# Altium schematic sheet MCU.SchDoc(MCU)
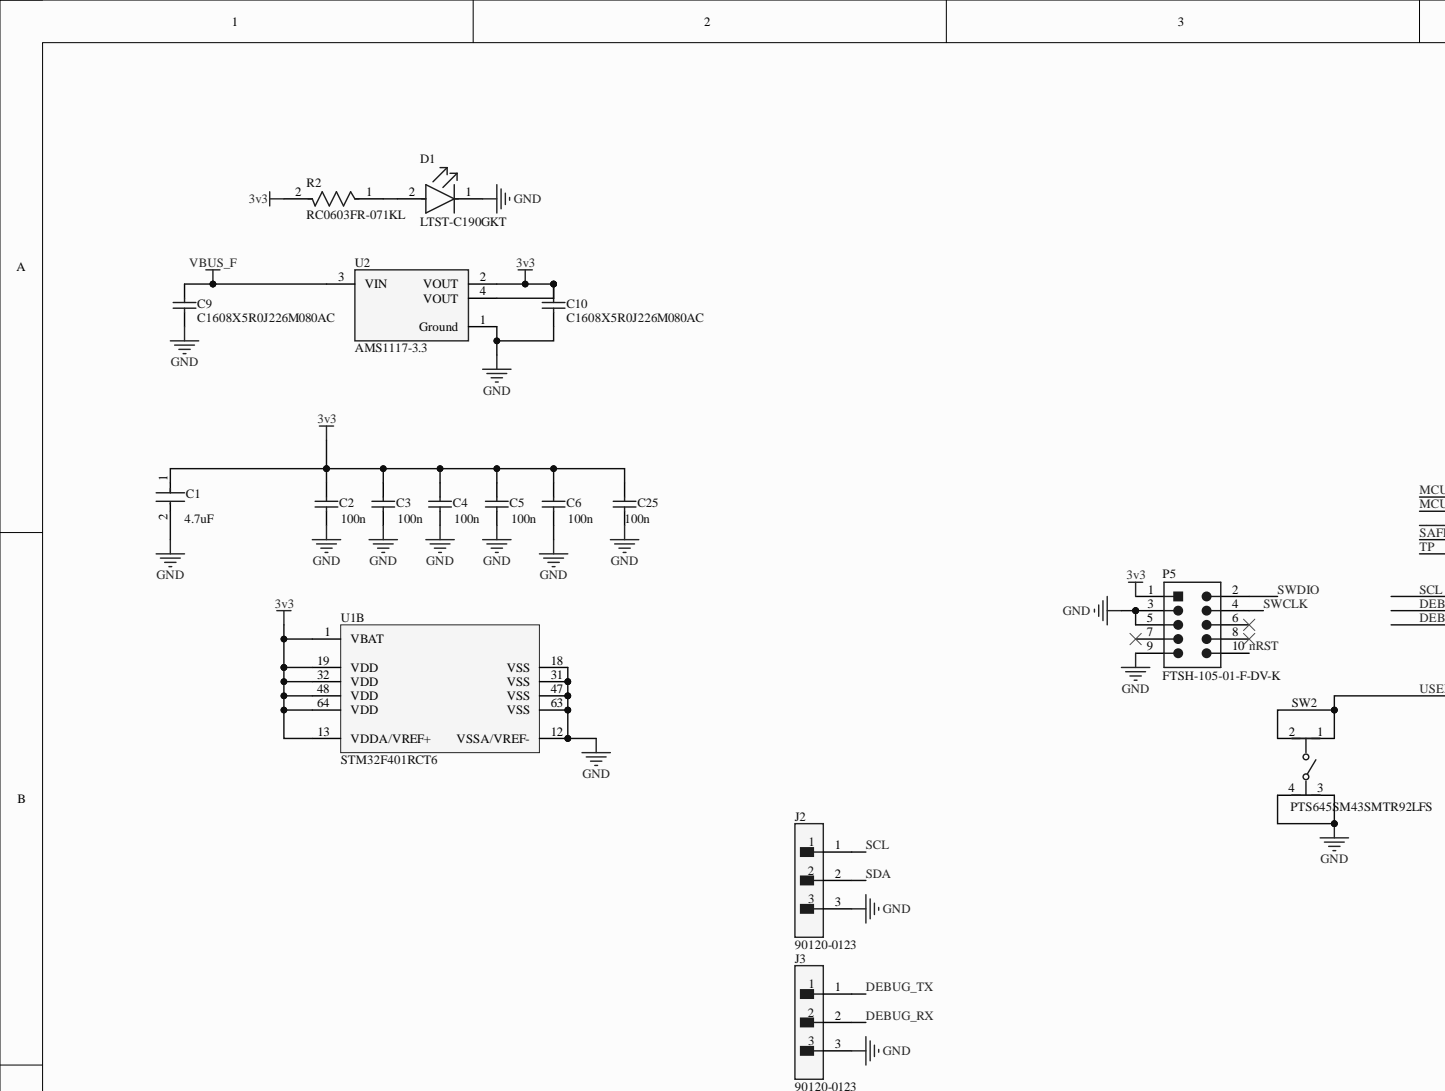
[diagram p0: MCU.SchDoc, top left]
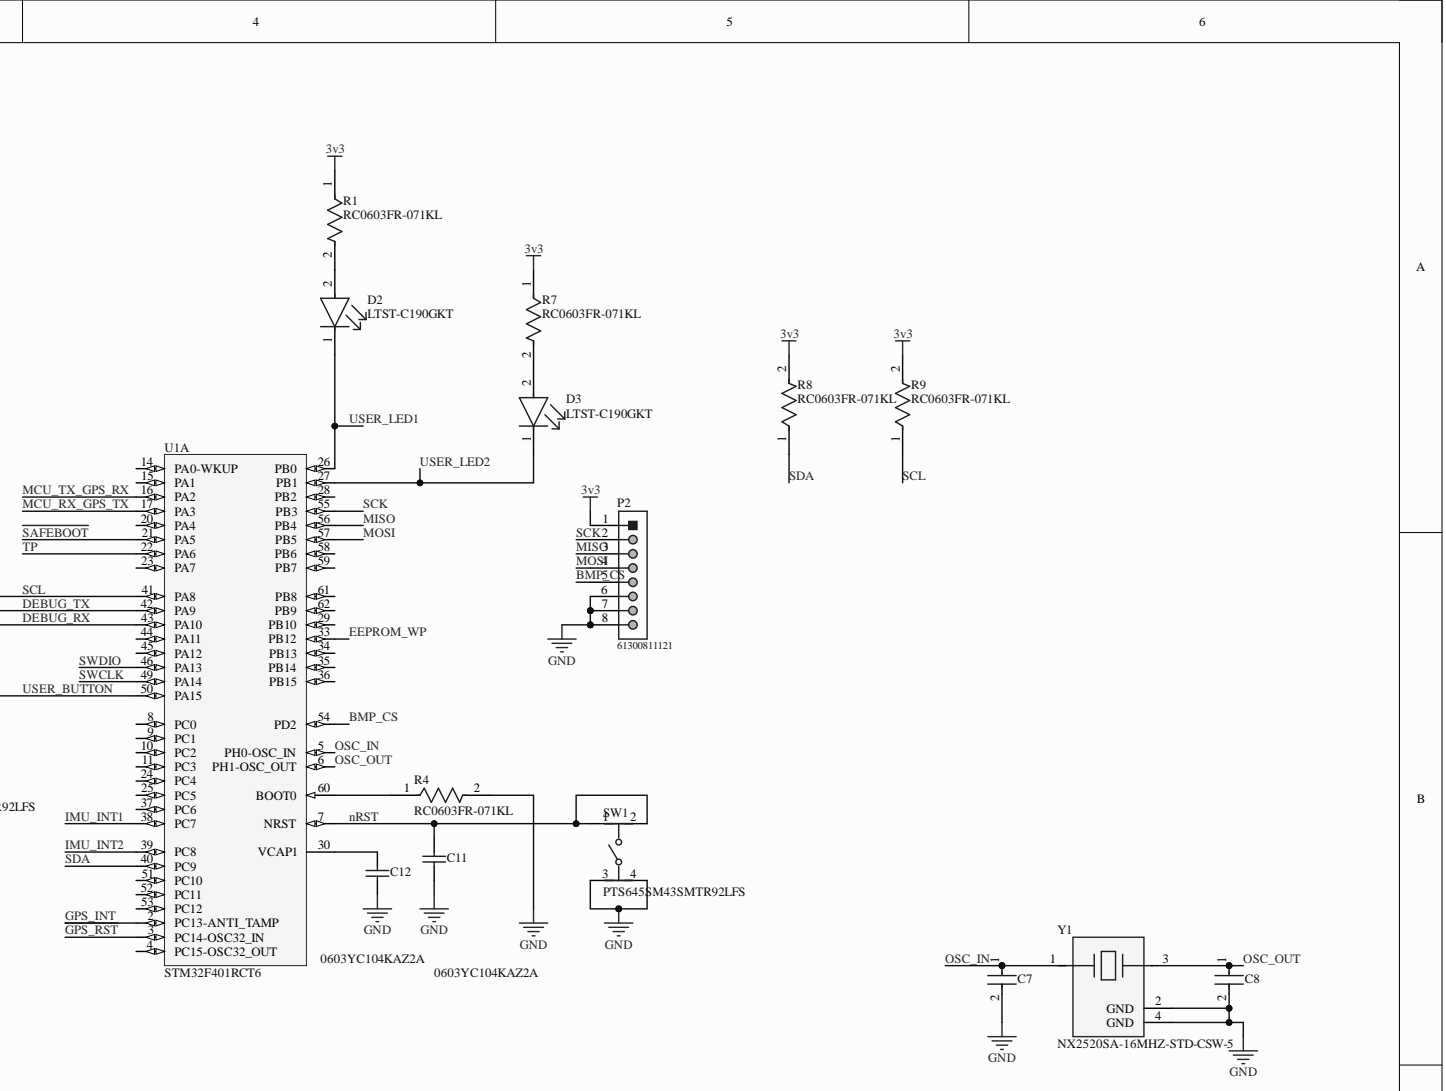
[diagram p1: MCU.SchDoc, top right]
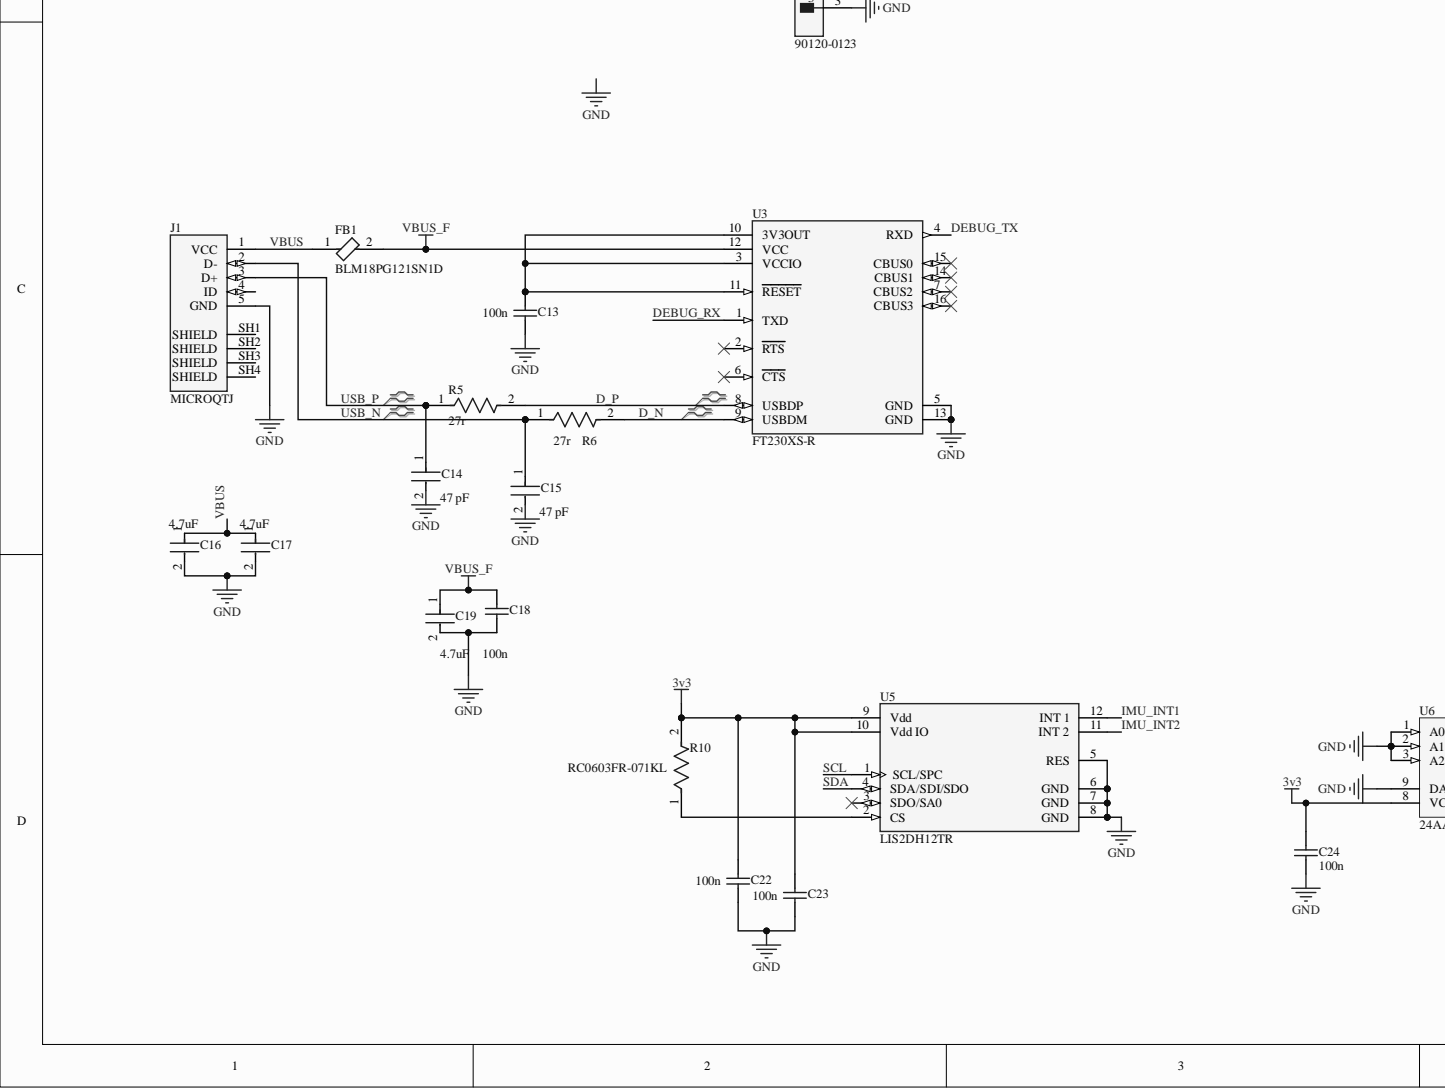
[diagram p2: MCU.SchDoc, bottom left]
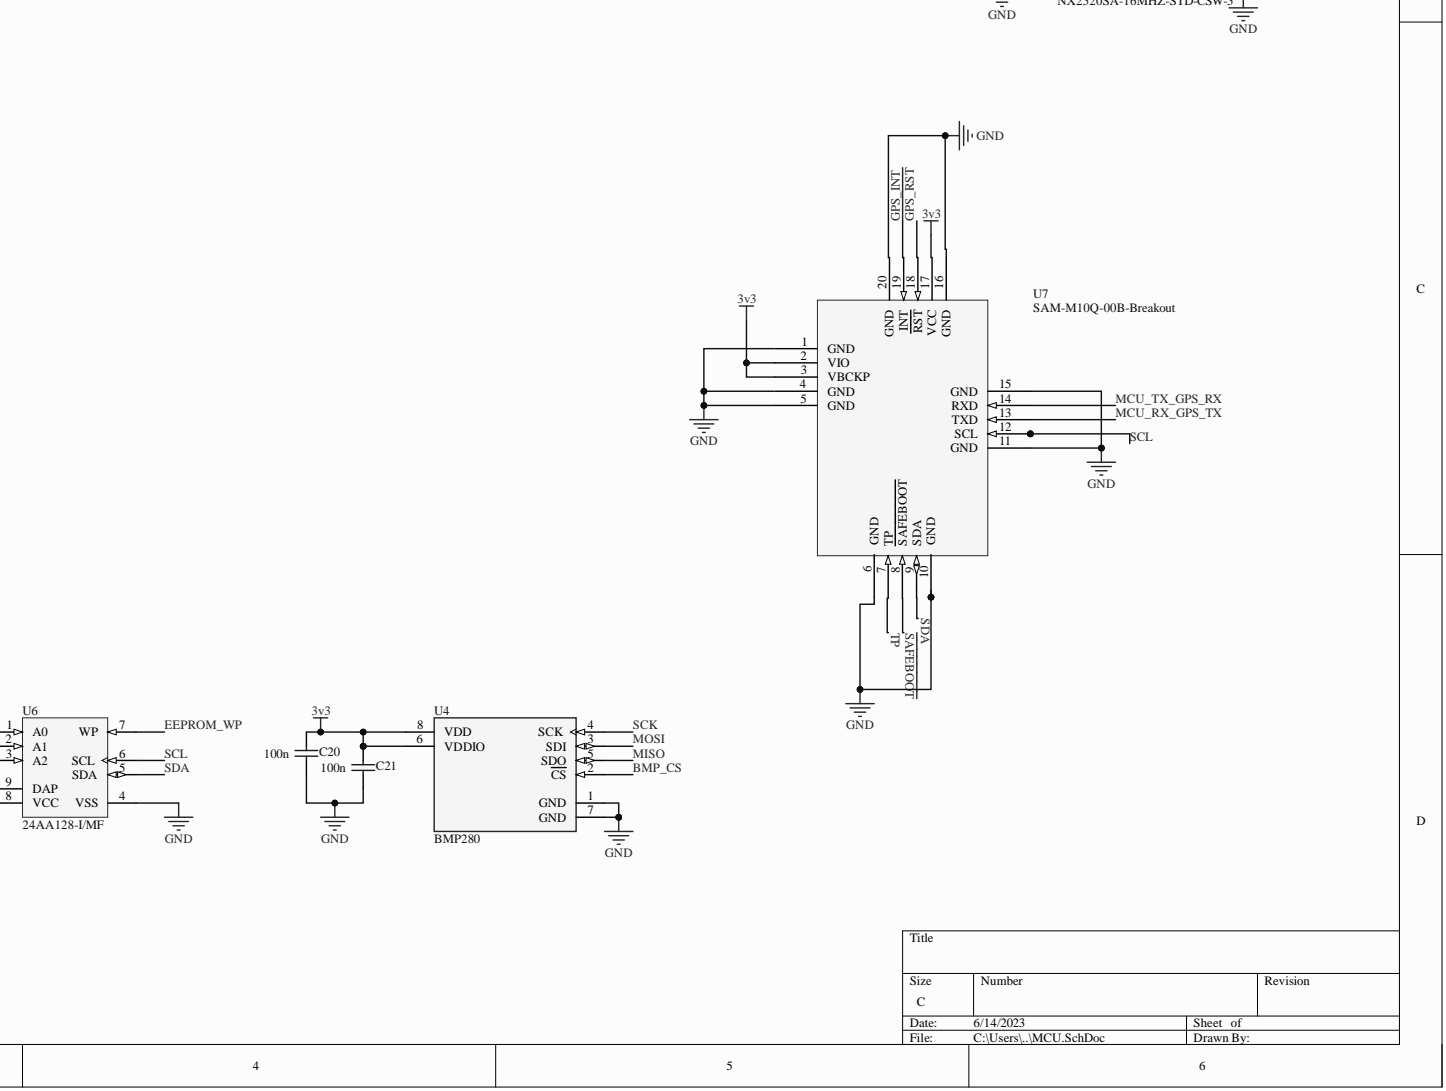
[diagram p3: MCU.SchDoc, bottom right]

## Parts
C1  value 4.7uF  Capacitance: 4.7uF  [FP-C0603-CG-MFG]
C2  100n  [CAPC1608X90X35ML15T15]
C3  100n  [CAPC1608X90X35ML15T15]
C4  100n  [CAPC1608X90X35ML15T15]
C5  100n  [CAPC1608X90X35ML15T15]
C6  100n  [CAPC1608X90X35ML15T15]
C7  (no value)  [FP-C1608-080-0_1-IPC_A]
C8  (no value)  [FP-C1608-080-0_1-IPC_A]
C9  C1608X5R0J226M080AC  [CAPC1608X100X20ML10]
C10  C1608X5R0J226M080AC  [CAPC1608X100X20ML10]
C11  0603YC104KAZ2A  [CAPC1608X90X35ML15T15]
C12  0603YC104KAZ2A  [CAPC1608X90X35ML15T15]
C13  100n  [CAPC1608X90X35ML15T15]
C14  value 47 pF  Capacitance: 47 pF  [FP-C0603C-CF-MFG]
C15  value 47 pF  Capacitance: 47 pF  [FP-C0603C-CF-MFG]
C16  value 4.7uF  Capacitance: 4.7uF  [FP-C0603-CG-MFG]
C17  value 4.7uF  Capacitance: 4.7uF  [FP-C0603-CG-MFG]
C18  100n  [CAPC1608X90X35ML15T15]
C19  value 4.7uF  Capacitance: 4.7uF  [FP-C0603-CG-MFG]
C20  100n  [CAPC1608X90X35ML15T15]
C21  100n  [CAPC1608X90X35ML15T15]
C22  100n  [CAPC1608X90X35ML15T15]
C23  100n  [CAPC1608X90X35ML15T15]
C24  100n  [CAPC1608X90X35ML15T15]
C25  100n  [CAPC1608X90X35ML15T15]
D1  LTST-C190GKT  [FP-LTST-C190GKT-MFG]
D2  LTST-C190GKT  [FP-LTST-C190GKT-MFG]
D3  LTST-C190GKT  [FP-LTST-C190GKT-MFG]
FB1  BLM18PG121SN1D  [FP-BLM18-0_15-t0_8-IPC_A]
J1  MICROQTJ  [SHOUHAN_MICROQTJ]
J2  90120-0123  [FP-90120-0123-MFG]
J3  90120-0123  [FP-90120-0123-MFG]
P2  61300811121  [61300811121]
P5  FTSH-105-01-F-DV-K  [SMTC-FTSH-105-01-L-DV-K_V]
R1  RC0603FR-071KL  [FP-RC0603-0_55-IPC_C]
R2  RC0603FR-071KL  [FP-RC0603-0_55-IPC_C]
R4  RC0603FR-071KL  [FP-RC0603-0_55-IPC_C]
R5  27r  [FP-CRCW0603-e3-IPC_B]
R6  27r  [FP-CRCW0603-e3-IPC_B]
R7  RC0603FR-071KL  [FP-RC0603-0_55-IPC_C]
R8  RC0603FR-071KL  [FP-RC0603-0_55-IPC_C]
R9  RC0603FR-071KL  [FP-RC0603-0_55-IPC_C]
R10  RC0603FR-071KL  [FP-RC0603-0_55-IPC_C]
SW1  PTS645SM43SMTR92LFS  [FP-PTS645SM43SMTR92LFS-MFG]
SW2  PTS645SM43SMTR92LFS  [FP-PTS645SM43SMTR92LFS-MFG]
U1  STM32F401RCT6  [STM-LQFP64_M]  (parts A,B)
U2  AMS1117-3.3  [FP-AMS1117-IPC_C]
U3  FT230XS-R  [FP-SSOP-16-MFG]
U4  BMP280  [FP-BMP280-MFG]
U5  LIS2DH12TR  [FP-LGA-12-8365767-MFG]
U6  24AA128-I/MF  [DFNS-MF8_PS]
U7  SAM-M10Q-00B-Breakout  [SAM-M10Q-00B]
Y1  NX2520SA-16MHZ-STD-CSW-5  [FP-NX2520SA-MFG]

## Nets
3v3: C1.1 C2.2 C3.2 C4.2 C5.2 C6.2 C10.1 C20.2 C21.2 C22.2 C23.2 C24.2 C25.2 P2.1 P5.1 R1.1 R2.2 R7.1 R8.2 R9.2 R10.2 U1.1(VBAT) U1.13(VDDA/VREF+) U1.19(VDD) U1.32(VDD) U1.48(VDD) U1.64(VDD) U2.2(VOUT) U2.4(VOUT) U4.6(VDDIO) U4.8(VDD) U5.9(Vdd) U5.10(Vdd_IO) U6.8(VCC) U7.2(VIO) U7.3(VBCKP) U7.17(VCC)
GND: C1.2 C2.1 C3.1 C4.1 C5.1 C6.1 C7.2 C8.2 C9.2 C10.2 C11.1 C12.1 C13.1 C14.2 C15.2 C16.2 C17.2 C18.1 C19.2 C20.1 C21.1 C22.1 C23.1 C24.1 C25.1 D1.1 J1.5(GND) J2.3 J3.3 P2.6 P2.7 P2.8 P5.3 P5.5 P5.9 R4.2 SW1.3 SW1.4 SW2.3 SW2.4 U1.12(VSSA/VREF-) U1.18(VSS) U1.31(VSS) U1.47(VSS) U1.63(VSS) U2.1(Ground) U3.5(GND) U3.13(GND) U4.1(GND) U4.7(GND) U5.5(RES) U5.6(GND) U5.7(GND) U5.8(GND) U6.1(A0) U6.2(A1) U6.3(A2) U6.4(VSS) U6.9(DAP) U7.5(GND) U7.6(GND) U7.10(GND) U7.11(GND) U7.15(GND) U7.16(GND) U7.20(GND) Y1.2(GND) Y1.4(GND)
VBUS_F: C9.1 C18.2 C19.1 FB1.2 U2.3(VIN) U3.12(VCC)
BMP_CS: P2.5 U1.54(PD2) U4.2(CS)
D_N: R6.2 U3.9(USBDM)
D_P: R5.2 U3.8(USBDP)
DEBUG_RX: J3.2 U1.43(PA10) U3.1(TXD)
DEBUG_TX: J3.1 U1.42(PA9) U3.4(RXD)
EEPROM_WP: U1.33(PB12) U6.7(WP)
~{GPS_RST}: U1.3(PC14-OSC32_IN) U7.18(RST)
GPS_INT: U1.2(PC13-ANTI_TAMP) U7.19(INT)
IMU_INT1: U1.38(PC7) U5.12(INT_1)
IMU_INT2: U1.39(PC8) U5.11(INT_2)
MCU_RX_GPS_TX: U1.17(PA3) U7.13(TXD)
MCU_TX_GPS_RX: U1.16(PA2) U7.14(RXD)
MISO: P2.3 U1.56(PB4) U4.5(SDO)
MOSI: P2.4 U1.57(PB5) U4.3(SDI)
nRST: C11.2 P5.10 SW1.1 SW1.2 U1.7(NRST)
OSC_IN: C7.1 U1.5(PH0-OSC_IN) Y1.1
OSC_OUT: C8.1 U1.6(PH1-OSC_OUT) Y1.3
~{SAFEBOOT}: U1.21(PA5) U7.8(SAFEBOOT)
SCK: P2.2 U1.55(PB3) U4.4(SCK)
SCL: J2.1 R9.1 U1.41(PA8) U5.1(SCL/SPC) U6.6(SCL) U7.12(SCL)
SDA: J2.2 R8.1 U1.40(PC9) U5.4(SDA/SDI/SDO) U6.5(SDA) U7.9(SDA)
SWCLK: P5.4 U1.49(PA14)
SWDIO: P5.2 U1.46(PA13)
TP: U1.22(PA6) U7.7(TP)
USB_N: C15.1 J1.2(D-) R6.1
USB_P: C14.1 J1.3(D+) R5.1
USER_BUTTON: SW2.1 SW2.2 U1.50(PA15)
USER_LED1: D2.1 U1.26(PB0)
USER_LED2: D3.1 U1.27(PB1)
VBUS: C16.1 C17.1 FB1.1 J1.1(VCC)
NetC12_2: C12.2 U1.30(VCAP1)
NetC13_2: C13.2 U3.3(VCCIO) U3.10(3V3OUT) U3.11(RESET)
NetD1_2: D1.2 R2.1
NetD2_2: D2.2 R1.2
NetD3_2: D3.2 R7.2
NetR4_1: R4.1 U1.60(BOOT0)
NetR10_1: R10.1 U5.2(CS)

Not connected: J1 4(ID) SH1(SHIELD) SH2(SHIELD) SH3(SHIELD) SH4(SHIELD); P5 6 7 8; U1 4(PC15-OSC32_OUT) 8(PC0) 9(PC1) 10(PC2) 11(PC3) 14(PA0-WKUP) 15(PA1) 20(PA4) 23(PA7) 24(PC4) 25(PC5) 28(PB2) 29(PB10) 34(PB13) 35(PB14) 36(PB15) 37(PC6) 44(PA11) 45(PA12) 51(PC10) 52(PC11) 53(PC12) 58(PB6) 59(PB7) 61(PB8) 62(PB9); U3 2(RTS) 6(CTS) 7(CBUS2) 14(CBUS1) 15(CBUS0) 16(CBUS3); U5 3(SDO/SA0); U7 1(GND) 4(GND)

## Library data of the parts
C1 C16 C17 C19 = CMP-2006-02256-2: CAP CER 4.7UF 6.3V X5R 0603; Manufacturer: Kemet; Manufacturer Part Number: C0603C475K9PACTU; Material: Ceramic; Temperature Coefficient: 15%; Tolerance: 10%; Automotive: No; Category: Cap; Component Kind: Standard; ComponentLink2Description: Datasheet; ComponentLink2URL: https://content.kemet.com/datasheets/KEM_C1006_X5R_SMD.pdf; Device Class L1: Passive Components; Device Class L2: Capacitors; Device Class L3: Ceramic Capacitors; Digikey Description: CAP CER 4.7UF 6.3V X5R 0603; Height: 0.9mm; Ipc Land Pattern Name: CAPC16080X80; Lead Free: Yes; Library Name: Altium Content Vault; Mouser Description: Multilayer Ceramic Capacitors MLCC - SMD/SMT 6.3volts 4.7uF X5R 10%; Octopart: https://octopart.com/c0603c475k9pactu-kemet-104242; Package: C0603-CG; RoHS: TRUE; Temperature: -55°C to +85°C; Temperature Characteristic: X5R; Temperature Range High: +85°C; Temperature Range Low: -55°C; Voltage Rating: 6.3V
C2-C6 C13 C18 C20-C25 = CMP-2006-04496-1: Manufacturer: AVX Interconnect / Elco; Part Number: 0603YC104KAZ2A; Component Kind: Standard; Library Name: Altium Content Vault
C7 C8 = CMP-08246-005081-1: Multilayer Ceramic Capacitors 8pF ±0.5pF 50V C0G SMD 0603; Insulation Resistance: 10GR; Capacitance: 8pF; Case Code (Imperial): 0603; Case Code (Metric): 1608; Case/Package: 0603; Component Kind: Standard; Construction: Flat; Depth: 0.8mm; Dielectric: C0G; Dielectric Material: Ceramic; Height: 0.8mm; Lead Free: Lead Free; Length: 1.6mm; Library Name: Altium Content Vault; Max Operating Temperature: 125°C; Min Operating Temperature: -55°C; Mount: Surface Mount; Package Quantity: 1; Packaging: Tape and Reel; Radiation Hardening: No; REACH SVHC: No SVHC; RoHS Compliant: Yes; Series: C; Termination: SMD/SMT; Thickness: 0.037inch; Voltage Rating: 50V; Voltage Rating (DC): 50V; Weight: 7.1E-05oz; Width: 0.031inch
C9 C10 = CMP-2000-05440-1: Chip Capacitor, 22 uF, +/- 20%, 6.3 V, -55 to 85 degC, 1608 (0603 Metric), RoHS, Tape and Reel; Manufacturer: TDK; PCN Part Number: MLCCPartNumberChange30/Nov/2012; Capacitance: 22µF; Design Resources: 20WNon-IsolatedBuckLEDDriverUsingPLLNK460KG; Kits: 445-9003-KIT-ND-KITCAPCERHICAPMLCCSMD; Online Catalog: CSeries,GeneralPurpose; Other Names: 445-8028-1; Product Training Modules: CSeriesGeneralApplicationsSEAT,CCV,andTVCLDesignToolsGuidetoReplacinganElectrolyticCapacitorwithanMLCC; Temperature Coefficient: X5R; Voltage - Rated: 6.3V; Applications: GeneralPurpose; Category: Capacitors; Component Kind: Standard; Datasheet URL: http://www.farnell.com/datasheets/1913358.pdf; Datasheet Version: Mar-15; Family: CeramicCapacitors; Features: -; Height - Seated (Max): -; Lead Spacing: -; Lead Style: -; Library Name: Altium Content Vault; Manufacturer URL: http://www.tdk.com/; Mounting Type: SurfaceMount,MLCC; Operating Temperature: -55degC~85degC; Package / Case: 0603(1608Metric); Package Description: 2-Pin Surface Mount Device, Body 1.6 x 0.8 mm; Package Reference: 603; Packaging: CutTape(CT); Ratings: -; RoHS Information: RoHSCertificate-MLCC; Series: C; Size / Dimension: 0.063"Lx0.031"W(1.60mmx0.80mm); Standard Package: 1; Thickness (Max): 0.039"(1.00mm); Tolerance: ±20%
C11 C12 = CMP-2006-04496-1: Manufacturer: AVX Interconnect / Elco; Component Kind: Standard; Library Name: Altium Content Vault; Part Number: 0603YC104KAZ2A
C14 C15 = CMP-2006-03321-2: CAP CER 47PF 100V 5% NP0 0603; Manufacturer: KEMET; Manufacturer Part Number: C0603C470J1GACTU; Automotive Grade: Grade 1; Material: Ceramic; Temperature Characteristic: C0G; Temperature Coefficient: 30ppm/°C; Tolerance: 0.05; Automotive: Yes; Category: Cap; Component Kind: Standard; ComponentLink2Description: Datasheet; ComponentLink2URL: https://api.kemet.com/component-edge/download/datasheet/C0603C100J5GACAUTO.pdf; Device Class L1: Passive Components; Device Class L2: Capacitors; Device Class L3: Ceramic Capacitors; Digikey Description: CAP CER 47PF 100V 5% NP0 0603; Height: 0.87mm; Lead Free: Yes; Library Name: Altium Content Vault; Mouser Description: Multilayer Ceramic Capacitors MLCC - SMD/SMT 100volts 47pF C0G 5%; Octopart: https://octopart.com/c0603c470j1gactu-kemet-3768219; Package: C0603C-CF; RoHS: TRUE; Temperature: -55°C to +125°C; Temperature Range High: +125°C; Temperature Range Low: -55°C; Voltage Rating: 100 V
D1-D3 = CMP-22017-000005-2: LED GREEN CLEAR CHIP SMD; Dominant Wavelength: 569nm; Forward Current: 30mA; Forward Voltage: 2.1V; Lens Color: Colorless; Luminous Intensity: 6mcd; Number of Elements: 1; Power Dissipation: 100mW; Reverse Voltage: 5V; Test Current: 10mA; Viewing Angle: 130°; Voltage: 2V; Wavelength: 565nm; Case Code (Imperial): 0603; Case Code (Metric): 1608; Case/Package: 0603; Color: Green; Component Kind: Standard; Depth: 0.8mm; Height: 0.8mm; Illumination Color: Green; Lead Free: Lead Free; Length: 1.6mm; Lens Style: Clear; Lens Transparency: Clear; Library Name: Altium Content Vault; Max Operating Temperature: 85°C; Min Operating Temperature: -55°C; Mount: Surface Mount; Number of LEDs: 1; Number of Pins: 2; Package Quantity: 1; Packaging: Tape and Reel; Power Rating: 100mW; Radiation Hardening: No; Width: 0.8mm
FB1 = CMP-0686-00557-7: Chip Ferrite Bead, 0603, 120Ω @ 100MHz, 0.05Ω, 25%, 2A; DC Resistance (DCR): 50mR; Impedance: 120R; Material: Steel; Number of Circuits: 1; Shielding: Unshielded; Case Code (Imperial): 0603; Case Code (Metric): 1608; Case/Package: 0603; Component Kind: Standard; Core Material: Ferrite; Current Rating: 2A; DC Current: 2A; Depth: 0.8mm; Frequency: 100MHz; Height: 0.8mm; Lead Free: Lead Free; Length: 1.6mm; Library Name: Altium Content Vault; Max Current Rating: 2A; Max DC Current: 2A; Max Operating Temperature: 125°C; Min Operating Temperature: -55°C; Number of Lines: 1; Number of Pins: 2; Package Quantity: 1; Packaging: Tape and Reel; Radiation Hardening: No; REACH SVHC: No SVHC; RoHS Compliant: Yes; Termination: SMD/SMT; Test Frequency: 100MHz; Tolerance: 25%; Width: 0.8mm
J1 = MICROQTJ: MF: shou han; Availability: Not in stock; Check_prices: https://www.snapeda.com/parts/MICROQTJ/Shouhan/view-part/?ref=eda; Component Kind: Standard; Library Name: MICROQTJ.IntLib; MP: MICROQTJ; Package: None; Price: None; SnapEDA_Link: https://www.snapeda.com/parts/MICROQTJ/Shouhan/view-part/?ref=snap
J2 J3 = CMP-04776-000237-1: CONN HEADER VERT 3POS 2.54MM; Contact Post Length: 2.9mm; Contact Resistance: 20mR; Current Rating: 3A; Gender: Male; Glow Wire Compliant: Non-compliant; Max Voltage Rating (DC): 350.35kV; Number of Circuits: 3; Voltage Rating: 350V; Color: Black; Component Kind: Standard; Contact Gender: Male; Contact Material: Brass; Contact Mating Length: 6.75mm; Contact Plating: Tin; Housing Color: Black; Housing Material: Polyester; Lead Free: Lead Free; Library Name: Altium Content Vault; Max Operating Temperature: 125°C; Min Operating Temperature: -55°C; Mount: Through Hole; Number of Contacts: 3; Number of Positions: 3; Number of Rows: 1; Orientation: Vertical; Packaging: Tray; Pitch: 2.54mm; Radiation Hardening: No; REACH SVHC: No SVHC; RoHS Compliant: Yes; Series: 90120; Termination: Solder; Voltage Rating (AC): 350V
P2 = CMP-1502-01076-1: THT Vertical Pin Header WR-PHD, Pitch 2.54 mm, Single Row, 8 pins; Manufacturer: Wurth Elektronik; Contact Resistance: 20mOhm; Gender: Male; Pin Number: 8; Pitch: 2.54mm; Rated Current: 3A; Withstanding Voltage: 500VAC; Working Voltage: 250VAC; Component Kind: Standard; Datasheet URL: https://katalog.we-online.de/em/datasheet/6130xx11121.pdf; Library Name: Altium Content Vault; Manufacturer URL: http://www.we-online.de; Mounting Technology: THT; Operating Temperature: -40to105degC; Orientation: Vertical; Package Reference: 61300811121; Packaging: Bag; Part Number: 61300811121; Row Number: Single; Publisher: WurthElektronik
P5 = CMP-2000-05352-1: Male Header, Pitch 1.27 mm, 2 x 5 Position, Height 6.12 mm, RoHS, Tube; Manufacturer: Samtec; Connector Type: Header,Shrouded; Number of Positions: 10; Number of Positions Loaded: All; Pitch: 0.050"(1.27mm); Category: Connectors,Interconnects; Color: Black; Component Kind: Standard; Contact Finish: Gold; Contact Finish Thickness: 3µin(0.08µm); Contact Mating Length: 0.120"(3.05mm); Contact Type: MalePin; Datasheet URL: http://suddendocs.samtec.com/prints/ftsh-1xx-xx-xxx-dv-xxx-mkt.pdf; Datasheet Version: Rev. ET, 10/1995; Family: RectangularConnectors-Headers,MalePins; Fastening Type: -; Features: -; Library Name: Altium Content Vault; Manufacturer URL: http://www.samtec.com/; Mounting Type: SurfaceMount; Number of Rows: 2; Package Description: Male Header, Pitch 1.27 mm, 2 x 5 Position, Height 5.97 mm, Tail Length 4.32 mm; Package Reference: FTSH-105-01-L-DV-K; Package URL: http://suddendocs.samtec.com/prints/ftsh-1xx-xx-xxx-dv-xxx-footprint.pdf; Packaging: Tube; Row Spacing: 0.050"(1.27mm); Series: FTSH; Termination: Solder
R1 R2 R4 R7-R10 = CMP-1659-00009-5: RES 1K OHM 1% 1/10W 0603; Max Power Dissipation: 100mW; Resistance: 1kR; Temperature Coefficient: 100ppm/°C; Voltage Rating: 50V; Case Code (Imperial): 0603; Case Code (Metric): 1608; Case/Package: 0603; Component Kind: Standard; Construction: Rectangular; Contact Plating: Tin; Depth: 0.8mm; Features: Moisture Resistant; Height: 0.45mm; Lead Free: Lead Free; Length: 1.6mm; Library Name: Altium Content Vault; Max Operating Temperature: 155°C; Military Standard: Not; Min Operating Temperature: -55°C; Number of Terminations: 2; Packaging: Tape and Reel; Power Rating: 100mW; Radiation Hardening: No; REACH SVHC: No SVHC; Resistance Tolerance: 1%; Resistor Type: Thick Film; RoHS Compliant: Yes; Series: RC; Size Code: 0603; Technology: THICK FILM; Termination: SMD/SMT; Tolerance: 1%
R5 R6 = CMP-2000-03145-2: RES Thick Film, 27Ω, 5%, 0.1W, 200ppm/°C, 0603; Max Power Dissipation: 100mW; Voltage Rating: 75V; Case Code (Imperial): 0603; Case Code (Metric): 1608; Case/Package: 0603; Component Kind: Standard; Composition: Thick Film; Contact Plating: Tin; Depth: 0.85mm; Features: Automotive AEC-Q200; Height: 0.45mm; Lead Free: Lead Free; Length: 1.55mm; Library Name: Altium Content Vault; Max Operating Temperature: 155°C; Military Standard: Not; Min Operating Temperature: -55°C; Number of Pins: 2; Number of Terminations: 2; Package Quantity: 1; Packaging: Tape and Reel; Power Rating: 100mW; Radiation Hardening: No; Resistance: 27R; Temperature Coefficient: 200ppm/°C; Termination: Solder; Tolerance: 5%; Voltage Rating (DC): 75V; Weight: 7.1E-05oz; Width: 0.85mm
SW1 SW2 = CMP-2000-05160-2: SWITCH TACTILE SPST-NO 0.05A 12V; Actuator Length: 0.85mm; Actuator Material: Nylon; Actuator Type: Standard; Contact Resistance: 100mR; Current Rating: 50mA; Illumination Type: Non-Illuminated; Mechanical Life: 100000Cycles; Operating Force: 160gf; Switch Function: Off-Mom; Throw Configuration: SPST; Case/Package: SMD/SMT; Color: Black; Component Kind: Standard; Contact Material: Silver; Contact Plating: Silver; Depth: 9mm; Lead Free: Lead Free; Length: 6mm; Library Name: Altium Content Vault; Max Current Rating: 50mA; Max Operating Temperature: 60°C; Max Voltage Rating (DC): 12V; Min Operating Temperature: -20°C; Mount: Surface Mount; Orientation: Straight; Package Quantity: 1; Packaging: Tape and Reel; REACH SVHC: No SVHC; Termination: Gull Wing; Voltage Rating (DC): 12V
U1 = CMP-0243-00091-2: ARM Cortex-M4 32-bit MCU+FPU, 105 DMIPS, 256 kB Flash, 64 kB Internal RAM, 48 I/Os, 64-pin LQFP, -40 to 85 degC, Tray; Manufacturer: STMicroelectronics; FLASH Size (Prog) (kB): 256; I/Os (High Current): 48; Internal RAM Size (kB): 64; Operating Frequency(F) (Processor speed) (MHz): 84; Supply Voltage(Vcc) max (V): 3.6; Supply Voltage(Vcc) min (V): 1.8; Component Kind: Standard; ComponentLink1Description: Manufacturer URL; ComponentLink1URL: http://www.st.com/web/en/home.html; ComponentLink2Description: Datasheet; ComponentLink2URL: http://www.st.com/st-web-ui/static/active/en/resource/technical/document/data_brief/DM00071938.pdf; ComponentLink3Description: Package Specification; ComponentLink3URL: http://www.st.com/st-web-ui/static/active/en/resource/technical/document/datasheet/DM00037051.pdf; Core: ARM Cortex-M4; DatasheetVersion: Rev. 1; Library Name: Altium Content Vault; Mounting Technology: Surface Mount; PackageDescription: 64-Pin Low Profile Quad Flat Package, 10 x 10 mm Body, 0.5 mm Pitch; PackageReference: LQFP64; PackageVersion: Rev. 4, 06/2013; Packing: Tray; PartNumber: STM32F401RCT6
U2 = CMP-209535-000001-1: LDO Voltage Regulators 1A, 3.3V; Manufacturer: Advanced Monolithic Systems; Dropout Voltage: 1.1V; Max Junction Temp: +125°C; Max Power Dissipation: 1.2W; Max Supply Voltage: 15V; Nominal Supply Current: 5mA; Number Of Outputs: 1; Output Type: Fixed; Standoff Height: 0.64mm; Automotive: No; Category: IC; Component Kind: Standard; ComponentLink2Description: Datasheet; ComponentLink2URL: http://www.advanced-monolithic.com/pdf/ds1117.pdf; Device Class L1: Integrated Circuits (ICs); Device Class L2: Power Management ICs; Device Class L3: Voltage Regulators - Linear; Height: 1.8mm; Ipc Land Pattern Name: SOT229P700X180-4; Lead Free: Yes; Library Name: Altium Content Vault; Manufacturer Part Number: AMS1117-3.3; Octopart: https://octopart.com/ams1117-3.3-advanced+monolithic+systems-25899300; Output Current: 1A; Output Voltage: 3.3V; Package: AMS1117; RoHS: TRUE; Temperature: -40°C to +125°C; Temperature Range High: +125°C; Temperature Range Low: -40°C
U3 = CMP-0248-00026-3: IC USB SERIAL BASIC UART 16SSOP; Data Rate: 12Mbps; Max Supply Voltage: 5.5V; Min Supply Voltage: 2.97V; Number of Channels: 1; Operating Supply Current: 8mA; Operating Supply Voltage: 5V; Case/Package: SSOP; Component Kind: Standard; Height: 0.059inch; Interface: UART; Length: 5mm; Library Name: Altium Content Vault; Max Operating Temperature: 85°C; Min Operating Temperature: -40°C; Mount: Surface Mount; Number of Pins: 16; Package Quantity: 3000; Packaging: Tape and Reel; Weight: 0.004586oz; Width: 0.157inch
U4 = CMP-2000-05076-3: SENSOR PRESSURE ABS; Max Pressure: 290.08PSI; Operating Pressure: 15.95PSI; Output Type: SPI; Port Style: No Port; Pressure Type: Absolute; Case/Package: SMD/SMT; Component Kind: Standard; Lead Free: Lead Free; Library Name: Altium Content Vault; Max Operating Temperature: 85°C; Min Operating Temperature: -40°C; Number of Pins: 8; Termination: SMD/SMT
U5 = CMP-2000-05369-2: ACCEL 2-16G I2C/SPI 12LGA; Axis: X, Y, Z; Bandwidth: 672Hz; Interface: I2C; Max Supply Voltage: 3.6V; Min Supply Voltage: 1.71V; Output Type: SPI; Case/Package: LGA; Component Kind: Standard; Contact Plating: Gold; Height: 1mm; Lead Free: Lead Free; Length: 2.15mm; Library Name: Altium Content Vault; Max Operating Temperature: 85°C; Min Operating Temperature: -40°C; Mount: Surface Mount; Number of Pins: 12; Packaging: Cut Tape; Radiation Hardening: No; REACH SVHC: No SVHC; Width: 2.15mm
U6 = CMP-0159-00373-1: 128Kbit, 400kHz, 1.7V, I2C Serial EEPROM, 8-Pin DFN Punch Singulated, Industrial Temperature; Component Kind: Standard; DatasheetVersion: revR, Apr-2009; Library Name: Altium Content Vault; PackageDescription: 8-Lead Plastic Dual Flat, No Lead Package (MF) - 6x5 mm Body [DFN-S] Punch Singulated; PackageReference: DFNS-MF8_PS; PackageVersion: revBB, Aug-2009
Y1 = CMP-2000-05279-2: CRYSTAL 16.0000MHZ 8PF SMD; ESR (Equivalent Series Resistance): 80R; Frequency: 16MHz; Frequency Stability: 25ppm; Frequency Tolerance: 15ppm; Case/Package: SMD/SMT; Component Kind: Standard; Height: 0.022inch; Length: 0.098inch; Library Name: Altium Content Vault; Load Capacitance: 8pF; Max Operating Temperature: 85°C; Min Operating Temperature: -40°C; Mount: Surface Mount; Number of Pins: 4; Package Quantity: 3000; Packaging: Tape and Reel; Series Resistance: 80R; Width: 0.079inch
U7 = SAM-M10Q-00B-Breakout: Component Kind: Standard; Library Name: STM32F4.SCHLIB
